# Altium schematic sheet CM5_GPIO.SchDoc(CM5_GPIO)
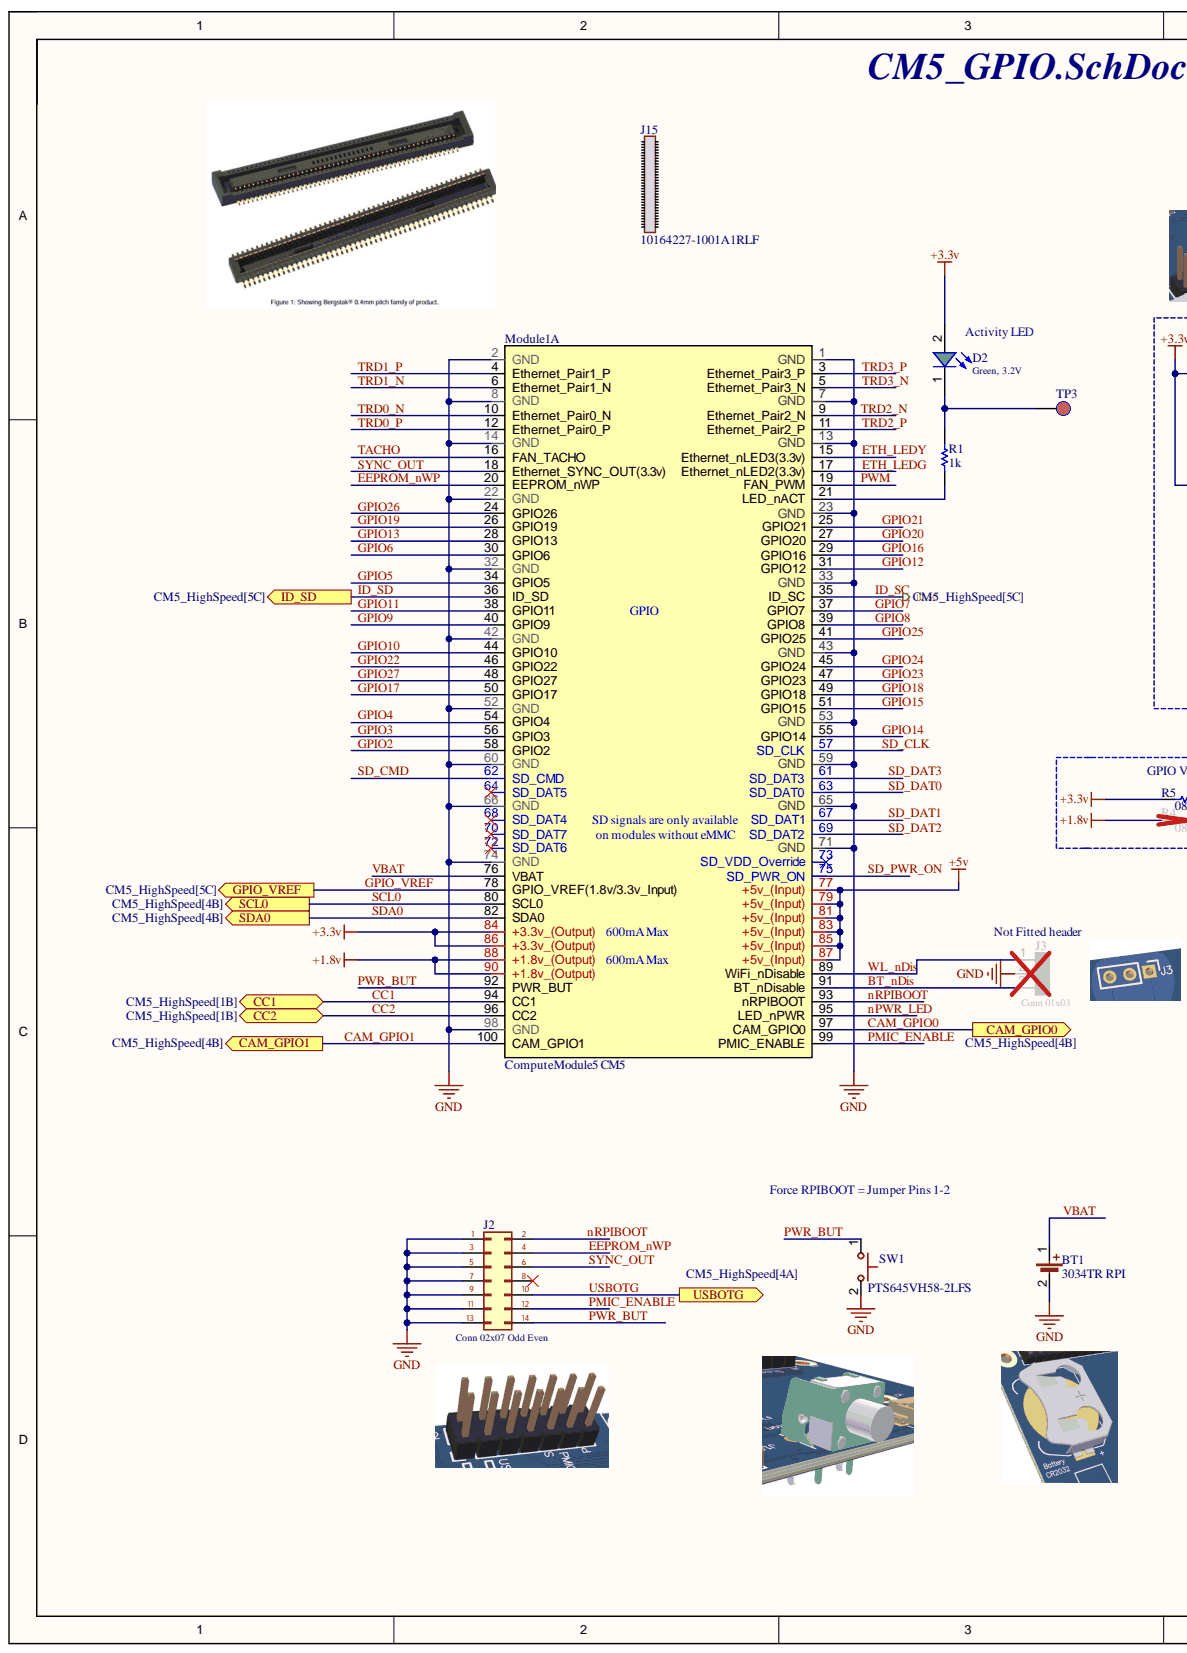
[diagram p0: CM5_GPIO.SchDoc, left]
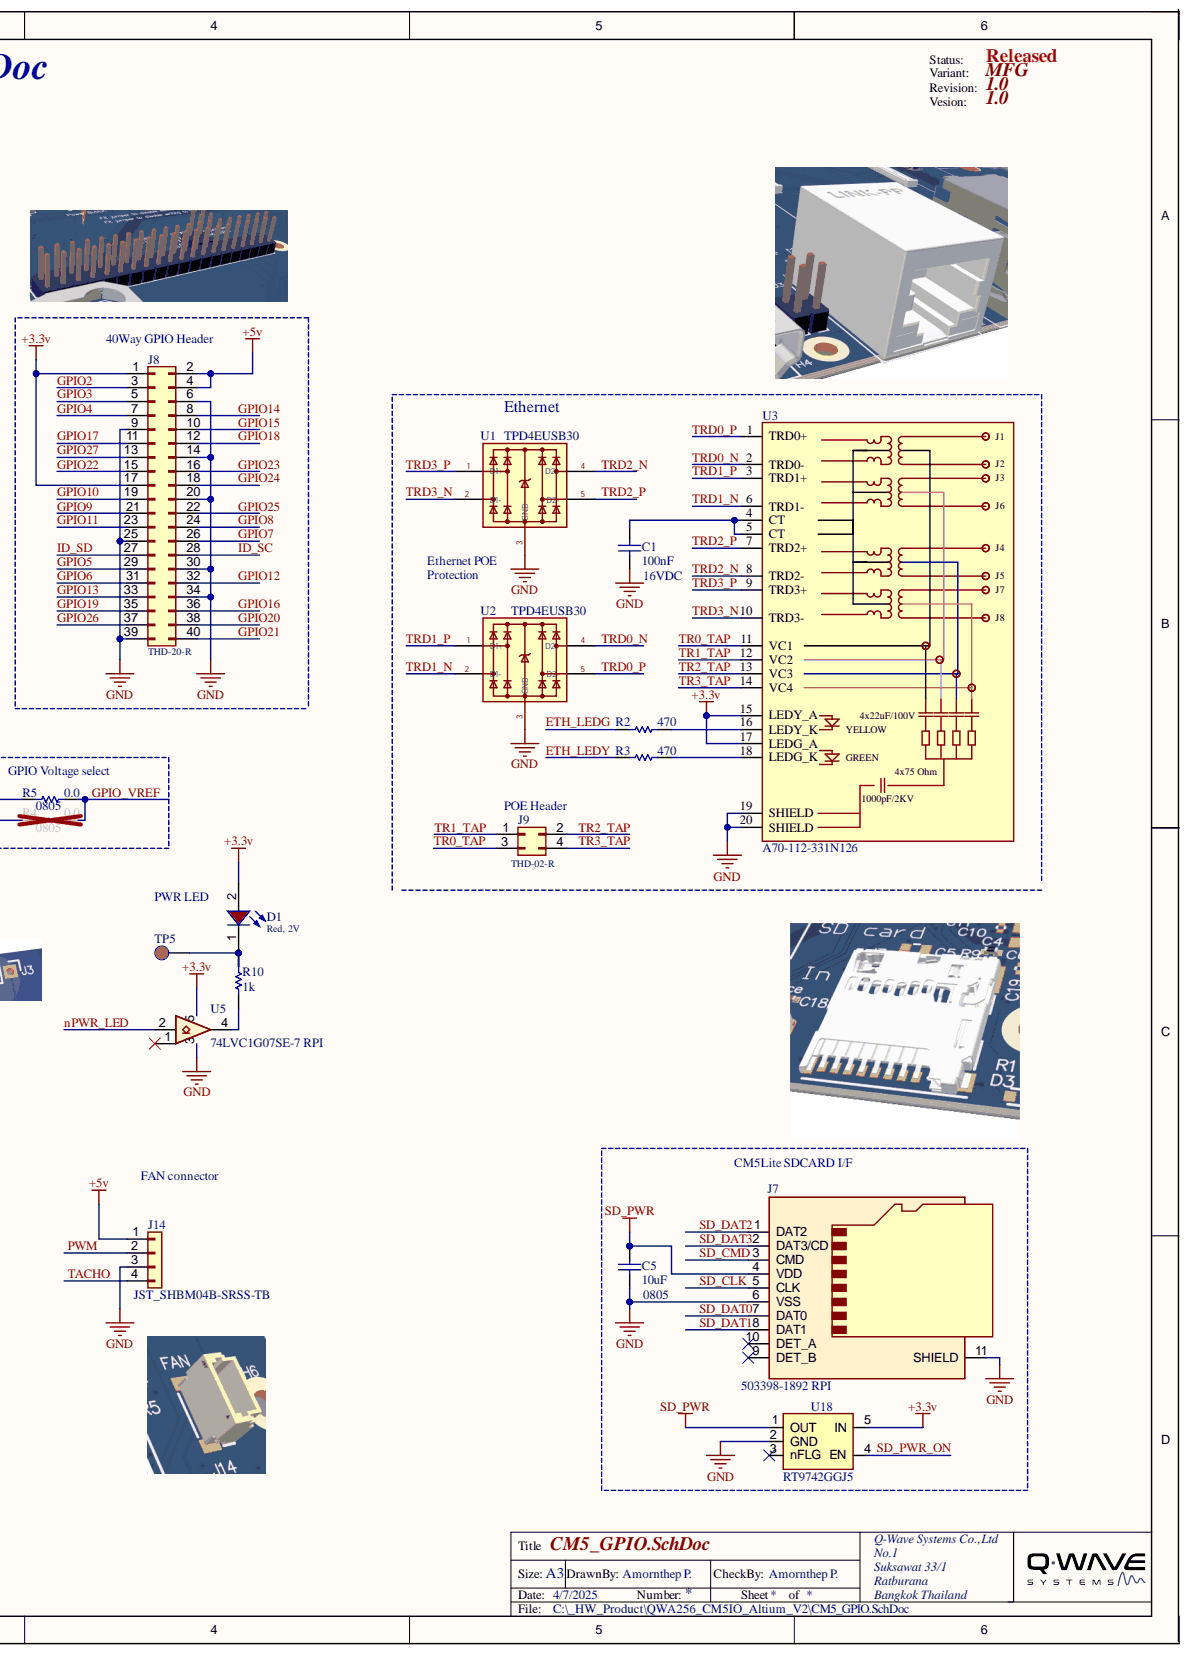
[diagram p1: CM5_GPIO.SchDoc, right]

## Parts
BT1  3034TR RPI  [3034TR RPI]
C1  value 100nF  Capacitance: 100nF  Rated Voltage: 16VDC  [WCAP-CSGP_0402_H=0.5mm]  (hidden comment: 100nF, 16V)
C5  value 10uF  Capacitance: 10uF  Size: 0805  [WCAP-CSGP_0805_H=1.25mm]  (hidden comment: 10uF, 16V)
D1  Red, 2V  Forward Voltage typ.: 2V  [WL-SMCW_0603_H=0.7mm_Red]
D2  Green, 3.2V  Forward Voltage typ.: 3.2V  [WL-SMCW_0603_H=0.7mm_Green]
J2  Conn 02x07 Odd Even  value 14  [Conn 02x07 Odd Even]
J3  Conn 01x03  value 3  [Conn 01x03]
J7  503398-1892 RPI  [503398-1892 RPI]
J8  THD-20-R  value 40  [THD-20-R]
J9  THD-02-R  value 4  [THD-02-R]
J14  JST_SHBM04B-SRSS-TB  [JST_SHBM04B-SRSS-TB]
J15  10164227-1001A1RLF  [CM5-BergStak-10164227]
Module1  ComputeModule5 CM5  [ComputeModule5-CM5]  (no BOM)  (part A)
R1  value 1k  [SMD-0402-RES]  (hidden comment: RES SMD 1K OHM 1% 1/10W 0402)
R2  value 470  [SMD-0402-RES]  (hidden comment: RES SMD 470 OHM 1% 1/10W 0402)
R3  value 470  [SMD-0402-RES]  (hidden comment: RES SMD 470 OHM 1% 1/10W 0402)
R4  value 0.0  Supplier Device Package: 0805  [SMD-0805-RES]  (hidden comment: ERJ-6GEY0R00V)
R5  value 0.0  Supplier Device Package: 0805  [SMD-0805-RES]  (hidden comment: ERJ-6GEY0R00V)
R10  value 1k  [SMD-0402-RES]  (hidden comment: RES SMD 1K OHM 1% 1/10W 0402)
SW1  PTS645VH58-2LFS  [PTS645VH58-2LFS]
TP3  TEST_POINT 1  [TEST_POINT 1]  (value not drawn)  (no BOM)
TP5  TEST_POINT 1  [TEST_POINT 1]  (value not drawn)  (no BOM)
U1  TPD4EUSB30  [TPD4EUSB30]
U2  TPD4EUSB30  [TPD4EUSB30]
U3  A70-112-331N126  [A70-112-331N126]
U5  74LVC1G07SE-7 RPI  [74LVC1G07SE-7 RPI]
U18  RT9742GGJ5  [FP-RT9742GGJ5-IPC_A]

## Ports
CAM_GPIO0, CAM_GPIO1, CC1, CC2, GPIO_VREF, ID_SC, ID_SD, SCL0, SDA0, USBOTG

## Nets
+1.8v: Module1.88(+1.8v_(Output)) Module1.90(+1.8v_(Output)) R4.1
+3.3v: D1.2 D2.2 J8.1 J8.17 Module1.84(+3.3v_(Output)) Module1.86(+3.3v_(Output)) R5.1 U3.15(LEDY_A) U3.17(LEDG_A) U5.5 U18.5(IN)
+5v: J8.2 J8.4 J14.1 Module1.77(+5v_(Input)) Module1.79(+5v_(Input)) Module1.81(+5v_(Input)) Module1.83(+5v_(Input)) Module1.85(+5v_(Input)) Module1.87(+5v_(Input))
GND: BT1.2 C1.1 C5.1 J2.1 J2.3 J2.5 J2.7 J2.9 J2.11 J2.13 J3.2 J7.6(VSS) J7.11(SHIELD) J8.6 J8.9 J8.14 J8.20 J8.25 J8.30 J8.34 J8.39 J14.3 Module1.1(GND) Module1.2(GND) Module1.7(GND) Module1.8(GND) Module1.13(GND) Module1.14(GND) Module1.22(GND) Module1.23(GND) Module1.32(GND) Module1.33(GND) Module1.42(GND) Module1.43(GND) Module1.52(GND) Module1.53(GND) Module1.59(GND) Module1.60(GND) Module1.65(GND) Module1.66(GND) Module1.71(GND) Module1.74(GND) Module1.98(GND) SW1.2 U1.3(GND) U2.3(GND) U3.19(SHIELD) U3.20(SHIELD) U5.3 U18.2(GND)
SD_PWR: C5.2 J7.4(VDD) U18.1(OUT)
BT_nDis: J3.3 Module1.91(BT_nDisable)
CAM_GPIO0: Module1.97(CAM_GPIO0) ; port CAM_GPIO0
CAM_GPIO1: Module1.100(CAM_GPIO1) ; port CAM_GPIO1
CC1: Module1.94(CC1) ; port CC1
CC2: Module1.96(CC2) ; port CC2
EEPROM_nWP: J2.4 Module1.20(EEPROM_nWP)
ETH_LEDG: Module1.17(Ethernet_nLED2(3.3v)) R2.1
ETH_LEDY: Module1.15(Ethernet_nLED3(3.3v)) R3.1
GPIO2: J8.3 Module1.58(GPIO2)
GPIO3: J8.5 Module1.56(GPIO3)
GPIO4: J8.7 Module1.54(GPIO4)
GPIO5: J8.29 Module1.34(GPIO5)
GPIO6: J8.31 Module1.30(GPIO6)
GPIO7: J8.26 Module1.37(GPIO7)
GPIO8: J8.24 Module1.39(GPIO8)
GPIO9: J8.21 Module1.40(GPIO9)
GPIO10: J8.19 Module1.44(GPIO10)
GPIO11: J8.23 Module1.38(GPIO11)
GPIO12: J8.32 Module1.31(GPIO12)
GPIO13: J8.33 Module1.28(GPIO13)
GPIO14: J8.8 Module1.55(GPIO14)
GPIO15: J8.10 Module1.51(GPIO15)
GPIO16: J8.36 Module1.29(GPIO16)
GPIO17: J8.11 Module1.50(GPIO17)
GPIO18: J8.12 Module1.49(GPIO18)
GPIO19: J8.35 Module1.26(GPIO19)
GPIO20: J8.38 Module1.27(GPIO20)
GPIO21: J8.40 Module1.25(GPIO21)
GPIO22: J8.15 Module1.46(GPIO22)
GPIO23: J8.16 Module1.47(GPIO23)
GPIO24: J8.18 Module1.45(GPIO24)
GPIO25: J8.22 Module1.41(GPIO25)
GPIO26: J8.37 Module1.24(GPIO26)
GPIO27: J8.13 Module1.48(GPIO27)
GPIO_VREF: Module1.78(GPIO_VREF(1.8v/3.3v_Input)) R4.2 R5.2 ; port GPIO_VREF
ID_SC: J8.28 Module1.35(ID_SC) ; port ID_SC
ID_SD: J8.27 Module1.36(ID_SD) ; port ID_SD
nPWR_LED: Module1.95(LED_nPWR) U5.2
nRPIBOOT: J2.2 Module1.93(nRPIBOOT)
PMIC_ENABLE: J2.12 Module1.99(PMIC_ENABLE)
PWM: J14.2 Module1.19(FAN_PWM)
PWR_BUT: J2.14 Module1.92(PWR_BUT) SW1.1
SCL0: Module1.80(SCL0) ; port SCL0
SD_CLK: J7.5(CLK) Module1.57(SD_CLK)
SD_CMD: J7.3(CMD) Module1.62(SD_CMD)
SD_DAT0: J7.7(DAT0) Module1.63(SD_DAT0)
SD_DAT1: J7.8(DAT1) Module1.67(SD_DAT1)
SD_DAT2: J7.1(DAT2) Module1.69(SD_DAT2)
SD_DAT3: J7.2(DAT3/CD) Module1.61(SD_DAT3)
SD_PWR_ON: Module1.75(SD_PWR_ON) U18.4(EN)
SDA0: Module1.82(SDA0) ; port SDA0
SYNC_OUT: J2.6 Module1.18(Ethernet_SYNC_OUT(3.3v))
TACHO: J14.4 Module1.16(FAN_TACHO)
TR0_TAP: J9.3 U3.11(VC1)
TR1_TAP: J9.1 U3.12(VC2)
TR2_TAP: J9.2 U3.13(VC3)
TR3_TAP: J9.4 U3.14(VC4)
TRD0_N: Module1.10(Ethernet_Pair0_N) U2.4(D2+) U3.2(TRD0-)
TRD0_P: Module1.12(Ethernet_Pair0_P) U2.5(D2-) U3.1(TRD0+)
TRD1_N: Module1.6(Ethernet_Pair1_N) U2.2(D1-) U3.6(TRD1-)
TRD1_P: Module1.4(Ethernet_Pair1_P) U2.1(D1+) U3.3(TRD1+)
TRD2_N: Module1.9(Ethernet_Pair2_N) U1.4(D2+) U3.8(TRD2-)
TRD2_P: Module1.11(Ethernet_Pair2_P) U1.5(D2-) U3.7(TRD2+)
TRD3_N: Module1.5(Ethernet_Pair3_N) U1.2(D1-) U3.10(TRD3-)
TRD3_P: Module1.3(Ethernet_Pair3_P) U1.1(D1+) U3.9(TRD3+)
USBOTG: J2.10 ; port USBOTG
VBAT: BT1.1 Module1.76(VBAT)
WL_nDis: J3.1 Module1.89(WiFi_nDisable)
NetC1_2: C1.2 U3.4(CT) U3.5(CT)
NetD1_1: D1.1 R10.2 TP5.1
NetD2_1: D2.1 R1.2 TP3.1
NetModule1_21: Module1.21(LED_nACT) R1.1
NetR2_2: R2.2 U3.16(LEDY_K)
NetR3_2: R3.2 U3.18(LEDG_K)
NetR10_1: R10.1 U5.4

Not connected: J2 8; J7 9(DET_B) 10(DET_A); Module1 64(SD_DAT5) 68(SD_DAT4) 70(SD_DAT7) 72(SD_DAT6) 73(SD_VDD_Override); U5 1; U18 3(nFLG)

## Library data of the parts
BT1 = CMP-00029-00004-2: Coin Cell Battery Holders COIN CELL RETAINER T APE/REEL 500PC; Number of Batteries: 1; Number of Contacts: 2; Case/Package: SMD/SMT; Component Kind: Standard; Contact Material: Nickel; Contact Plating: Tin; Height: 4.31mm; Lead Free: Lead Free; Material: Bronze; Mounting Technology: Surface Mount,PCB; Number of Cells: 1; Package Quantity: 500; Packaging: Tape and Reel; Series: -; Termination: SMD/SMT; Library Name: Q-WAVE SYSTEMS CO.,LTD
C1 = CMP-01409-2: WCAP-CSGP Multilayer Ceramic Chip Capacitor, General Purpose, size 0402, X5R, 100nF, 16V; Manufacturer: Wurth Elektronik; Manufacturer Part Number: 885012105016; Capacitance Tolerance: +/-20%; Dissipation Factor max.: 5%; Isolation Resistance  min.: 5GOhm; Technical Reference: X5R0402104M016DFCT10000; Ceramic Type: X5R; Component Kind: Standard; Component Type: Standard; ComponentLink1Description: Manufacturer; ComponentLink1URL: http://www.we-online.de; ComponentLink2Description: Datasheet; ComponentLink2URL: https://www.we-online.com/catalog/datasheet/885012105016.pdf; Design Item ID: 885012105016; Height: 0.5mm; Mounting Technology: SMT; Operating Temperature: -55 to +85degC; Packaging: Tape and Reel; Pin Count: 2; Published Date: April 2021; Q-Factor min.: -; Size: 0402; Source: WCAP-CSGP_0402.SchLib; Library Name: Q-WAVE SYSTEMS CO.,LTD
C5 = CMP-01449-2: WCAP-CSGP Multilayer Ceramic Chip Capacitor, General Purpose, size 0805, X5R, 10uF, 16V; Manufacturer: Wurth Elektronik; Manufacturer Part Number: 885012107014; Capacitance Tolerance: +/-20%; Dissipation Factor max.: 10%; Isolation Resistance  min.: 0.01GOhm; Rated Voltage: 16VDC; Technical Reference: X5R0805106M016DFCT10000; Ceramic Type: X5R; Component Kind: Standard; Component Type: Standard; ComponentLink1Description: Manufacturer; ComponentLink1URL: http://www.we-online.de; ComponentLink2Description: Datasheet; ComponentLink2URL: https://www.we-online.com/catalog/datasheet/885012107014.pdf; Design Item ID: 885012107014; Height: 1.25mm; Mounting Technology: SMT; Operating Temperature: -55 to +85degC; Packaging: Tape and Reel; Pin Count: 2; Published Date: April 2021; Q-Factor min.: -; Source: WCAP-CSGP_0805.SchLib; Library Name: Q-WAVE SYSTEMS CO.,LTD
D1 = CMP-00986-4: WL-SMCW SMT Mono-color Chip LED Waterclear, size 0603, Red, 2V, 140deg; Part Number: 150060RS75000; Chip Technology: AlInGaP; Dominant Wavelength typ.: 625nm; ES P/N: 0176-0439-6; Luminous Intensity typ.: 250mcd; Peak Wavelength typ.: 630nm; SM P/N: 6949-59100742; Component Kind: Standard; Component Type: Standard; ComponentLink1Description: Manufacturer; ComponentLink1URL: http://www.we-online.de; ComponentLink2Description: Datasheet; ComponentLink2URL: https://www.we-online.com/catalog/datasheet/150060RS75000.pdf; Design Item ID: 150060RS75000; Emitting Color: Red; Mounting Technology: SMT; Operating Temperature: -40 to +85degC; Packaging: Tape and Reel; Pin Count: 2; Published Date: December 2019; Size: 0603; Source: WL-SMCW_0603.SchLib; Value: Red, 2V; Viewing Angle: 140deg; Library Name: Q-WAVE SYSTEMS CO.,LTD; Publisher: Wurth Elektronik
D2 = CMP-00983-5: WL-SMCW SMT Mono-color Chip LED Waterclear, size 0603, Green, 3.2V, 140deg; Part Number: 150060GS75000; Chip Technology: InGaN; Dominant Wavelength typ.: 525nm; ES P/N: 0176-1070-9; Luminous Intensity typ.: 430mcd; Peak Wavelength typ.: 515nm; SM P/N: 59100121; Component Kind: Standard; Component Type: Standard; ComponentLink1Description: Manufacturer; ComponentLink1URL: http://www.we-online.de; ComponentLink2Description: Datasheet; ComponentLink2URL: https://www.we-online.com/catalog/datasheet/150060GS75000.pdf; Design Item ID: 150060GS75000; Emitting Color: Green; Mounting Technology: SMT; Operating Temperature: -40 to +85degC; Packaging: Tape and Reel; Pin Count: 2; Published Date: December 2019; Size: 0603; Source: WL-SMCW_0603.SchLib; Value: Green, 3.2V; Viewing Angle: 140deg; Library Name: Q-WAVE SYSTEMS CO.,LTD; Publisher: Wurth Elektronik
J2 = CMP-002-00171-1: PinHeader 2x07 P2.54mm Vertical; Manufacturer: Wurth Elektronik; Manufacturer Part Number: 61301421121; Contact Resistance: 20mOhm; Pitch: 2.54mm; Rated Current: 3A; Row Number: Dual; Withstanding Voltage: 500VAC; Working Voltage: 250VAC; Component Kind: Standard; Component Type: Standard; ComponentLink1Description: Manufacturer; ComponentLink1URL: http://www.we-online.de; ComponentLink2Description: Datasheet; ComponentLink2URL: https://www.we-online.com/catalog/datasheet/61301421121.pdf; Design Item ID: 61301421121; Gender: Pin Header; Mounting Technology: THT; Operating Temperature: -40 to +105degC; Orientation: Vertical; Packaging: Bag; Pin Count: 14; Pin Number: 14; Published Date: May 2020; Source: WR-PHD_Pin Header_2.54mm.SchLib; Library Name: Q-WAVE SYSTEMS CO.,LTD
J3 = CMP-002-00173-1: PinHeader_1x03_P2.54mm_Vertical; Manufacturer: Wurth Elektronik; Manufacturer Part Number: 61300311121; Contact Resistance: 20mOhm; Pitch: 2.54mm; Rated Current: 3A; Row Number: Single; Withstanding Voltage: 500VAC; Working Voltage: 250VAC; Component Kind: Standard; Component Type: Standard; ComponentLink1Description: Manufacturer; ComponentLink1URL: http://www.we-online.de; ComponentLink2Description: Datasheet; ComponentLink2URL: https://www.we-online.com/catalog/datasheet/61300311121.pdf; Design Item ID: 61300311121; Gender: Pin Header; Mounting Technology: THT; Operating Temperature: -40 to +105degC; Orientation: Vertical; Packaging: Bag; Pin Count: 3; Pin Number: 3; Published Date: May 2020; Source: WR-PHD_Pin Header_2.54mm.SchLib; Library Name: Q-WAVE SYSTEMS CO.,LTD
J7 = CMP-002-00170-1: 1.10mm Pitch microSD Memory Card Connector, Normal Mount Surface Mount, Push-Push Type, 1.28mm Height; Current Rating: 500mA; Gender: Male; Number of Circuits: 8; Pitch: 1.1mm; Voltage Rating (AC): 10V; Component Kind: Standard; Contact Material: Bronze,Stainless Steel; Contact Plating: Gold; Depth: 14.05mm; Halogen Free: Low Halogen; Housing Material: Thermoplastic; Length: 13.6mm; Max Operating Temperature: 85°C; Min Operating Temperature: -25°C; Mounting Technology: Surface Mount; Number of Contacts: 8; Number of Rows: 1; Orientation: Right Angle; Package Quantity: 2400; Packaging: Tape and Reel; Reach SVHC Compliant: Unknown; RoHS Compliant: Yes; Series: 503398; Termination: Solder; Width: 14.05mm; Library Name: Q-WAVE SYSTEMS CO.,LTD
J8 = CMP-002-00167-3: Through hole straight pin header, 2x20, 2.54mm pitch, double rows; Manufacturer: Wurth Elektronik; Manufacturer Part Number: 61304021121; Contact Resistance: 20mOhm; Rated Current: 3A; Row Number: Dual; Withstanding Voltage: 500VAC; Working Voltage: 250VAC; Component Kind: Standard; Component Type: Standard; ComponentLink1Description: Manufacturer; ComponentLink1URL: http://www.we-online.de; ComponentLink2Description: Datasheet; ComponentLink2URL: https://www.we-online.com/catalog/datasheet/61304021121.pdf; Design Item ID: 61304021121; Gender: Pin Header; Mounting Technology: THT; Operating Temperature: -40 to +105degC; Orientation: Vertical; Packaging: Bag; Pin Count: 40; Pin Number: 40; Pitch: 2.54mm; Published Date: May 2020; Source: WR-PHD_Pin Header_2.54mm.SchLib; Library Name: Q-WAVE SYSTEMS CO.,LTD
J9 = CMP-002-00174-1: PinHeader 2x02 P2.54mm Vertical; Manufacturer: Wurth Elektronik; Manufacturer Part Number: 61300421121; Contact Resistance: 20mOhm; Pitch: 2.54mm; Rated Current: 3A; Row Number: Dual; Withstanding Voltage: 500VAC; Working Voltage: 250VAC; Component Kind: Standard; Component Type: Standard; ComponentLink1Description: Manufacturer; ComponentLink1URL: http://www.we-online.de; ComponentLink2Description: Datasheet; ComponentLink2URL: https://www.we-online.com/catalog/datasheet/61300421121.pdf; Design Item ID: 61300421121; Gender: Pin Header; Mounting Technology: THT; Operating Temperature: -40 to +105degC; Orientation: Vertical; Packaging: Bag; Pin Count: 4; Pin Number: 4; Published Date: May 2020; Source: WR-PHD_Pin Header_2.54mm.SchLib; Library Name: Q-WAVE SYSTEMS CO.,LTD
J14 = CMP-002-00172-1: Board Connector, 4 Contact(s), 1 Row(s), Male, Straight, Surface Mount Terminal; Number of Contacts: 4; Pitch: 0.039inch; Color: Natural; Component Kind: Standard; Contact Material: Copper Alloy; Contact Plating: Tin,Lead; Contact Type: Male Pin; Housing Color: Natural; Housing Material: Nylon; Lead Free: Contains Lead; Mounting Technology: Surface Mount; Number of Positions: 4; Number of Rows: 1; Orientation: Vertical; Package Quantity: 1500; Packaging: Cut Tape; Series: SR; Termination: Solder; Library Name: Q-WAVE SYSTEMS CO.,LTD
J15 = CMP-634-000024-1: CM5 1.5mm Height 0.4MM Btb, 100P, Receptacle, 1.5MM Stack Height; Component Kind: Standard; Library Name: Q-WAVE SYSTEMS CO.,LTD
Module1 = CMP-633-000139-6: Raspberry Pi Compute Module 5; Library Name: Q-WAVE SYSTEMS CO.,LTD
R1 R10 = CMP-236-000247-2: Manufacturer: Panasonic Electronic Components; Part Number: ERJ-2RKF1001X; @ qty: 1500; Factory Stock: 0; Minimum Quantity: 1; Power (Watts): 0.1W, 1/10W; Temperature Coefficient: ±100ppm/°C; Unit Price (USD): 0.00496; Component Kind: Standard; Composition: Thick Film; Datasheets: http://industrial.panasonic.com/www-cgi/jvcr13pz.cgi?E+PZ+3+AOA0002+ERJ2RKF1001X+7+WW; Description: RES SMD 1K OHM 1% 1/10W 0402; Digi-Key Part Number: P1.00KLCT-ND; Failure Rate: -; Features: Automotive AEC-Q200; Height - Seated (Max): 0.016" (0.40mm); Image: //media.digikey.com/Photos/Panasonic%20Photos/ERJ-PA2-Series.jpg; Number of Terminations: 2; Operating Temperature: -55°C ~ 155°C; Package / Case: 0402 (1005 Metric); Packaging: Cut Tape (CT); Part Status: Active; Quantity Available: 1787831; Series: ERJ; Size / Dimension: 0.039" L x 0.020" W (1.00mm x 0.50mm); Supplier Device Package: 0402; Tolerance: ±1%; Library Name: Q-WAVE SYSTEMS CO.,LTD
R2 R3 = CMP-236-000627-2: Manufacturer: Panasonic Electronic Components; Part Number: ERJ-2RKF4700X; @ qty: 1500; Factory Stock: 0; Minimum Quantity: 1; Power (Watts): 0.1W, 1/10W; Temperature Coefficient: ±100ppm/°C; Unit Price (USD): 0.00496; Component Kind: Standard; Composition: Thick Film; Datasheets: http://industrial.panasonic.com/www-cgi/jvcr13pz.cgi?E+PZ+3+AOA0002+ERJ2RKF4700X+7+WW; Description: RES SMD 470 OHM 1% 1/10W 0402; Digi-Key Part Number: P470LCT-ND; Failure Rate: -; Features: Automotive AEC-Q200; Height - Seated (Max): 0.016" (0.40mm); Image: //media.digikey.com/Photos/Panasonic%20Photos/ERJ-PA2-Series.jpg; Number of Terminations: 2; Operating Temperature: -55°C ~ 155°C; Package / Case: 0402 (1005 Metric); Packaging: Cut Tape (CT); Part Status: Active; Quantity Available: 52644; Series: ERJ; Size / Dimension: 0.039" L x 0.020" W (1.00mm x 0.50mm); Supplier Device Package: 0402; Tolerance: ±1%; Library Name: Q-WAVE SYSTEMS CO.,LTD
R4 R5 = CMP-775-000874-3: RES SMD 0.0 OHM JUMPER 1/8W 0805; Manufacturer: Panasonic Electronic Components; @ qty: 1500; ES P/N: 0150-1835-2; Factory Stock: 0; Minimum Quantity: 1; Power (Watts): 0.125W, 1/8W; Unit Price (USD): 0.00544; Component Kind: Standard; Component Type: Standard; Composition: Thick Film; Datasheets: http://industrial.panasonic.com/www-cgi/jvcr13pz.cgi?E+PZ+3+AOA0001+ERJ6GEY0R00V+7+WW; Design Item ID: ERJ-6GEY0R00V; Digi-Key Part Number: P0.0ACT-ND; Failure Rate: -; Features: Automotive AEC-Q200; Height - Seated (Max): 0.028" (0.70mm); Image: //media.digikey.com/Renders/Panasonic%20Renders/ERJ-0805%20Pkg.jpg; Number of Terminations: 2; Operating Temperature: -55?C ~ 155?C; Package / Case: 0805 (2012 Metric); Packaging: Cut Tape (CT); Part Number: ERJ-6GEY0R00V; Part Status: Active; Pin Count: 2; Quantity Available: 1597627; Series: ERJ; Size / Dimension: 0.079" L x 0.049" W (2.00mm x 1.25mm); Source: Panasonic_ERJ_0805.SchLib; Temperature Coefficient: -; Tolerance: Jumper; Library Name: Q-WAVE SYSTEMS CO.,LTD
SW1 = CMP-643-000011-1: PTS645 Series Compact 6 x 6 mm SPST 2 N Through Hole Tactile Switch; Actuator Material: Nylon,Polyamide,PA66; Actuator Type: Standard; Current Rating: 50mA; Illumination Type: Non-Illuminated,Illuminated; Mechanical Life: 100000Cycles; Operating Force: 200gf; Switch Function: Off-Mom; Voltage Rating (DC): 12V; Color: Black; Component Kind: Standard; Contact Material: Brass,Silver; Contact Plating: Silver; Depth: 9.8mm; Lead Free: Lead Free; Length: 7.5mm; Max Current Rating: 50mA; Max Operating Temperature: 60°C; Min Operating Temperature: -20°C; Mounting Technology: Through Hole; Orientation: Right Angle; Package Quantity: 1000; Packaging: Bulk; Reach SVHC Compliant: No; RoHS Compliant: Yes; Series: PTS645; Size: 2.5mm; Termination: PC Pin; Throw Configuration: SPST; Library Name: Q-WAVE SYSTEMS CO.,LTD
TP3 TP5 = CMP-633-000141-1: Test Point SMT PAD; Component Type: Standard (No BOM); Design Item ID: TEST_POINT; Pin Count: 1; Library Name: Q-WAVE SYSTEMS CO.,LTD
U1 U2 = CMP-02720-1: TEXAS INSTRUMENTS         TPD4EUSB30DQAR             ESD Protection Device, Array, 8 V, SON, 10 Pins; Breakdown Voltage: 7V; Capacitance: 0.8pF; Clamping Voltage: 8V; Current Rating: 5A; Data Rate: 6Gbps; Direction: Unidirectional; ES P/N: 0086-0901-1; ESD Protection: Yes; Interface: USB; Number of Bidirectional Channels: 4; Peak Pulse Current: 1A; Peak Pulse Power: 45W; Reverse Standoff Voltage: 5.5V; SM P/N: 6949-44043002; Component Kind: Standard; Depth: 1mm; Height: 0.5mm; Lead Free: Lead Free; Length: 2.5mm; Max Operating Temperature: 85°C; Min Breakdown Voltage: 8V; Min Operating Temperature: -40°C; Number of Channels: 4; Number of Pins: 10; Package Quantity: 1; Packaging: Tape and Reel; Power Line Protection: Yes; Radiation Hardening: No; REACH SVHC: No SVHC; RoHS Compliant: Yes; Termination: SMD/SMT; Width: 1.1mm; Working Voltage: 5.5V; Library Name: Q-WAVE SYSTEMS CO.,LTD
U3 = CMP-002-00168-2: TRJG0926HENL Single Port Ethernet(PoE+) RJ45 Female Connector with 10/100/1000 Base-T Integrated Magnetics, Green/Yellow LED, Tab Down, RoHS; TE P/N: 5-2337992-8; Component Kind: Standard; ComponentLink1Description: https://www.l-p.com/sale-432379-link-pp-lpjg0926henl-cross-a70-112-331n126-edac.htm; ComponentLink1URL: https://www.l-p.com/upload/file/2019/11-26/002050785.pdf; ComponentLink2Description: TRXCOM; ComponentLink2URL: https://p.globalsources.com/IMAGES/PDT/SPEC/690/K1160305690.pdf; EDAC P/N: A70-112-331N126; Library Name: Q-WAVE SYSTEMS CO.,LTD
U5 = CMP-633-000142-2: 74LVC Series 5.5 V Surface Mount Single Buffer with Open Drain Output - SOT-353; Logic Function: Buffer,Inverting; Low Level Output Current: 32mA; Max Supply Voltage: 5.5V; Min Supply Voltage: 1.65V; Number of Bits per Element: 1; Operating Supply Current: 10uA; Polarity: Inverting,Non-Inverting; Propagation Delay: 3ns; Quiescent Current: 200uA; Turn-On Delay Time: 8.5ns; Case/Package: SOT-353; Component Kind: Standard; Height: 1mm; Lead Free: Lead Free; Length: 2.2mm; Max Operating Temperature: 85°C; Min Operating Temperature: -40°C; Mounting Technology: Surface Mount; Number of Channels: 1; Number of Elements: 1; Number of Gates: 1; Number of Input Lines: 1; Number of Inputs: 1; Number of Output Lines: 1; Number of Outputs: 1; Package Quantity: 3000; Packaging: Tape and Reel; Pins: 5; Radiation Hardening: No; Reach SVHC Compliant: No; RoHS Compliant: Yes; Series: 74LVC; Technology: CMOS; Width: 1.35mm; Library Name: Q-WAVE SYSTEMS CO.,LTD
U18 = CMP-000462-2: IC Pwr Swtch N-chan 1: 1 TSOT23-5; Fault Protection: Over Temperature,Reverse Current; Interface: On/Off; Max Output current: 1A; Number of Outputs: 1; On-State Resistance: 70mR; Output Configuration: High Side; Case/Package: SOT-23-5; Component Kind: Standard; Max Operating Temperature: 85°C; Min Operating Temperature: -40°C; Packaging: Cut Tape; Pins: 23; Reach SVHC Compliant: No; RoHS Compliant: Yes; Series: -; Library Name: Q-WAVE SYSTEMS CO.,LTD
